# Altium schematic sheet ACTIVATOR.SchDoc
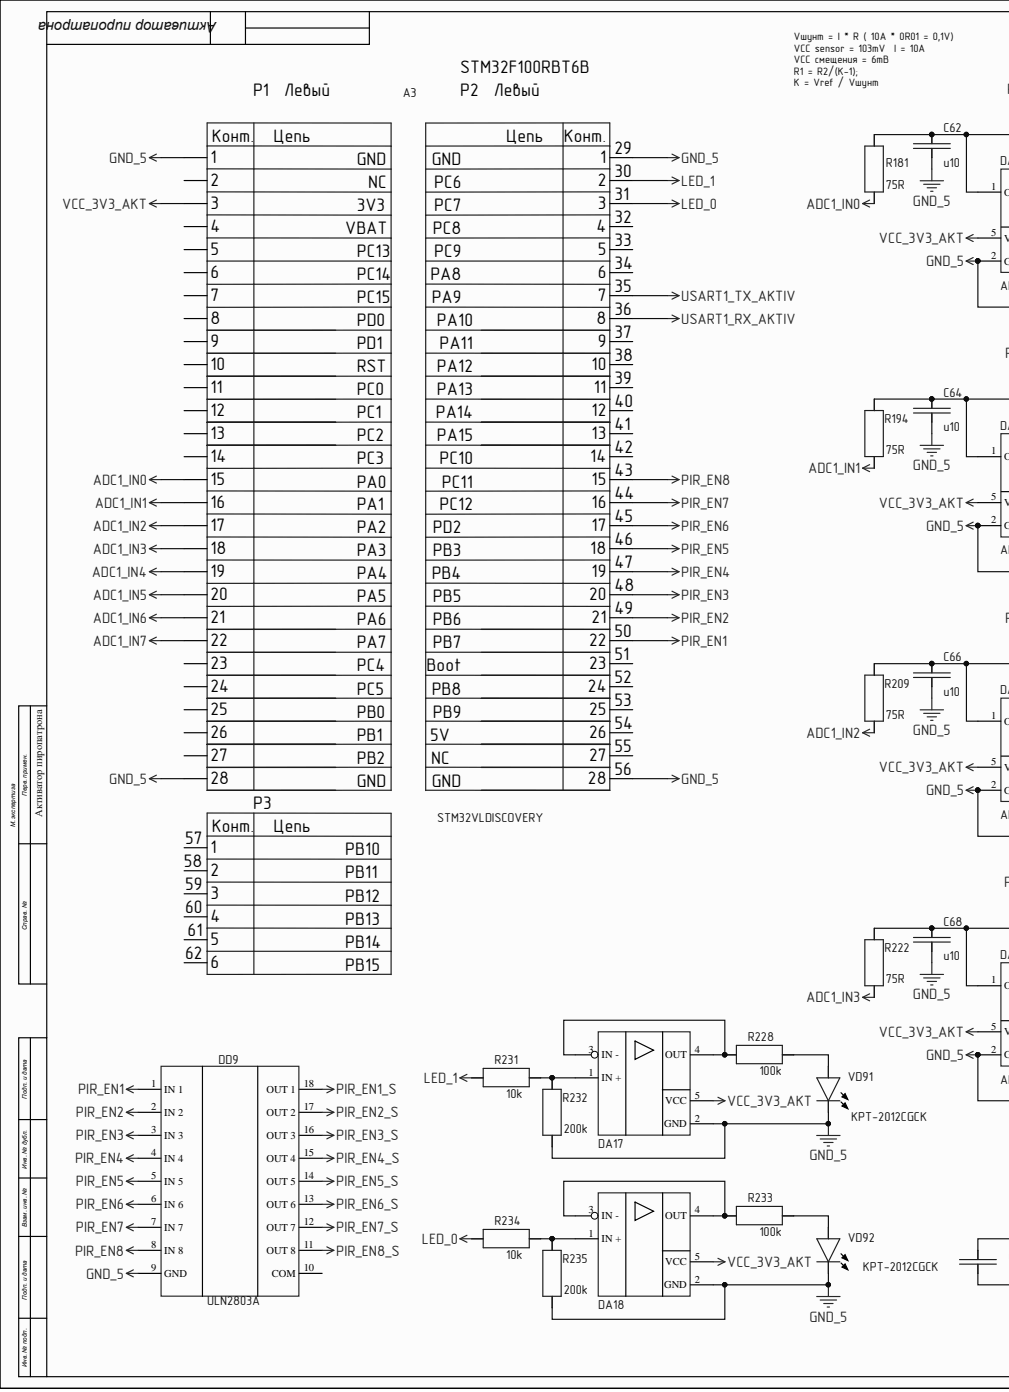
[diagram p0: ACTIVATOR.SchDoc, left]
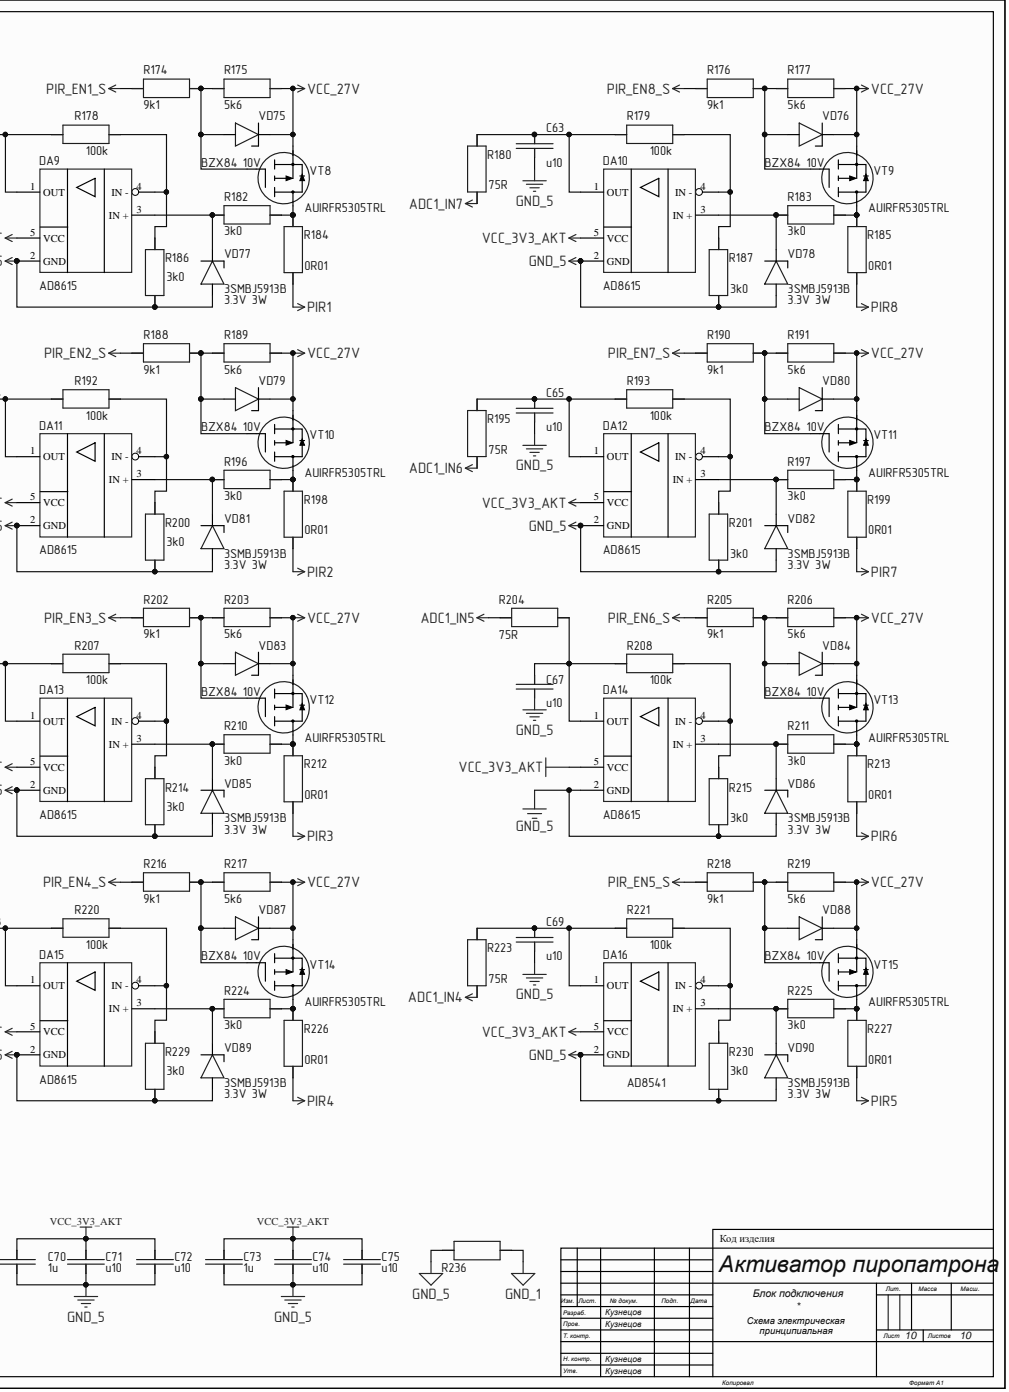
[diagram p1: ACTIVATOR.SchDoc, right]

## Parts
A3  STM32VLDISCOVERY  [STM32VLDISCOVERY]
C62  u10
C63  u10
C64  u10
C65  u10
C66  u10
C67  u10
C68  u10
C69  u10
C70  1u
C71  u10
C72  u10
C73  1u
C74  u10
C75  u10
DA9  AD8615  [SOT-23-5]
DA10  AD8615  [SOT-23-5]
DA11  AD8615  [SOT-23-5]
DA12  AD8615  [SOT-23-5]
DA13  AD8615  [SOT-23-5]
DA14  AD8615  [SOT-23-5]
DA15  AD8615  [SOT-23-5]
DA16  AD8541  [SOT-23-5]
DA17  TSV631  [SOT-23-5]  (value not drawn)
DA18  TSV631  [SOT-23-5]  (value not drawn)
DD9  ULN2803A  [SOIC-18]
R174  9k1  [0805]
R175  5k6  [0805]
R176  9k1  [0805]
R177  5k6  [0805]
R178  100k  [0805]
R179  100k  [0805]
R180  75R  [0805]
R181  75R  [0805]
R182  3k0  [0805]
R183  3k0  [0805]
R184  0R01  [R2010]
R185  0R01  [R2010]
R186  3k0  [0805]
R187  3k0  [0805]
R188  9k1  [0805]
R189  5k6  [0805]
R190  9k1  [0805]
R191  5k6  [0805]
R192  100k  [0805]
R193  100k  [0805]
R194  75R  [0805]
R195  75R  [0805]
R196  3k0  [0805]
R197  3k0  [0805]
R198  0R01  [R2010]
R199  0R01  [R2010]
R200  3k0  [0805]
R201  3k0  [0805]
R202  9k1  [0805]
R203  5k6  [0805]
R204  75R  [0805]
R205  9k1  [0805]
R206  5k6  [0805]
R207  100k  [0805]
R208  100k  [0805]
R209  75R  [0805]
R210  3k0  [0805]
R211  3k0  [0805]
R212  0R01  [R2010]
R213  0R01  [R2010]
R214  3k0  [0805]
R215  3k0  [0805]
R216  9k1  [0805]
R217  5k6  [0805]
R218  9k1  [0805]
R219  5k6  [0805]
R220  100k  [0805]
R221  100k  [0805]
R222  75R  [0805]
R223  75R  [0805]
R224  3k0  [0805]
R225  3k0  [0805]
R226  0R01  [R2010]
R227  0R01  [R2010]
R228  100k  [0805]
R229  3k0  [0805]
R230  3k0  [0805]
R231  10k  [0805]
R232  200k  [0805]
R233  100k  [0805]
R234  10k  [0805]
R235  200k  [0805]
R236  (no value)  [R2010]
VD75  BZX84  value BZX84 10V  [SOT23]
VD76  BZX84  value BZX84 10V  [SOT23]
VD77  3SMBJ5913B  [DO-214AА(SMB)]
VD78  3SMBJ5913B  Power\Voltage: 3.3V 3W  [DO-214AА(SMB)]
VD79  BZX84  value BZX84 10V  [SOT23]
VD80  BZX84  value BZX84 10V  [SOT23]
VD81  3SMBJ5913B  [DO-214AА(SMB)]
VD82  3SMBJ5913B  [DO-214AА(SMB)]
VD83  BZX84  value BZX84 10V  [SOT23]
VD84  BZX84  value BZX84 10V  [SOT23]
VD85  3SMBJ5913B  [DO-214AА(SMB)]
VD86  3SMBJ5913B  Power\Voltage: 3.3V 3W  [DO-214AА(SMB)]
VD87  BZX84  value BZX84 10V  [SOT23]
VD88  BZX84  value BZX84 10V  [SOT23]
VD89  3SMBJ5913B  Power\Voltage: 3.3V 3W  [DO-214AА(SMB)]
VD90  3SMBJ5913B  Power\Voltage: 3.3V 3W  [DO-214AА(SMB)]
VD91  KPT-2012CGCK  [0805_LED_GREEN]
VD92  KPT-2012CGCK  [0805_LED_GREEN]
VT8  AUIRFR5305TRL  [TO252AA (D-PAK)]
VT9  AUIRFR5305TRL  [TO252AA (D-PAK)]
VT10  AUIRFR5305TRL  [TO252AA (D-PAK)]
VT11  AUIRFR5305TRL  [TO252AA (D-PAK)]
VT12  AUIRFR5305TRL  [TO252AA (D-PAK)]
VT13  AUIRFR5305TRL  [TO252AA (D-PAK)]
VT14  AUIRFR5305TRL  [TO252AA (D-PAK)]
VT15  AUIRFR5305TRL  [TO252AA (D-PAK)]

## Nets
ADC1_IN0: A3.15 R181.2
ADC1_IN1: A3.16 R194.2
ADC1_IN2: A3.17 R209.2
ADC1_IN3: A3.18 R222.2
ADC1_IN4: A3.19 R223.2
ADC1_IN5: A3.20 R204.2
ADC1_IN6: A3.21 R195.2
ADC1_IN7: A3.22 R180.2
GND_1: R236.2
GND_5: A3.1 A3.28 A3.29 A3.56 C62.2 C63.2 C64.2 C65.2 C66.2 C67.2 C68.2 C69.2 C70.1 C71.1 C72.1 C73.1 C74.1 C75.1 DA9.2(GND) DA10.2(GND) DA11.2(GND) DA12.2(GND) DA13.2(GND) DA14.2(GND) DA15.2(GND) DA16.2(GND) DA17.2(GND) DA18.2(GND) DD9.9(GND) R186.2 R187.2 R200.2 R201.2 R214.2 R215.2 R229.2 R230.2 R232.2 R235.2 R236.1 VD77.1 VD78.1 VD81.1 VD82.1 VD85.1 VD86.1 VD89.1 VD90.1 VD91.2 VD92.2
LED_0: A3.31 R234.2
LED_1: A3.30 R231.2
PIR1: R184.1
PIR2: R198.1
PIR3: R212.1
PIR4: R226.1
PIR5: R227.1
PIR6: R213.1
PIR7: R199.1
PIR8: R185.1
PIR_EN1: A3.50 DD9.1(IN_1)
PIR_EN1_S: DD9.18(OUT_1) R174.2
PIR_EN2: A3.49 DD9.2(IN_2)
PIR_EN2_S: DD9.17(OUT_2) R188.2
PIR_EN3: A3.48 DD9.3(IN_3)
PIR_EN3_S: DD9.16(OUT_3) R202.2
PIR_EN4: A3.47 DD9.4(IN_4)
PIR_EN4_S: DD9.15(OUT_4) R216.2
PIR_EN5: A3.46 DD9.5(IN_5)
PIR_EN5_S: DD9.14(OUT_5) R218.2
PIR_EN6: A3.45 DD9.6(IN_6)
PIR_EN6_S: DD9.13(OUT_6) R205.2
PIR_EN7: A3.44 DD9.7(IN_7)
PIR_EN7_S: DD9.12(OUT_7) R190.2
PIR_EN8: A3.43 DD9.8(IN_8)
PIR_EN8_S: DD9.11(OUT_8) R176.2
USART1_RX_AKTIV: A3.36
USART1_TX_AKTIV: A3.35
VCC_3V3_AKT: A3.3 C70.2 C71.2 C72.2 C73.2 C74.2 C75.2 DA9.5(VCC) DA10.5(VCC) DA11.5(VCC) DA12.5(VCC) DA13.5(VCC) DA14.5(VCC) DA15.5(VCC) DA16.5(VCC) DA17.5(VCC) DA18.5(VCC)
VCC_27V: R175.1 R177.1 R189.1 R191.1 R203.1 R206.1 R217.1 R219.1 VD75.3 VD76.3 VD79.3 VD80.3 VD83.3 VD84.3 VD87.3 VD88.3 VT8.3 VT9.3 VT10.3 VT11.3 VT12.3 VT13.3 VT14.3 VT15.3
NetC62_1: C62.1 DA9.1(OUT) R178.2 R181.1
NetC63_1: C63.1 DA10.1(OUT) R179.2 R180.1
NetC64_1: C64.1 DA11.1(OUT) R192.2 R194.1
NetC65_1: C65.1 DA12.1(OUT) R193.2 R195.1
NetC66_1: C66.1 DA13.1(OUT) R207.2 R209.1
NetC67_1: C67.1 DA14.1(OUT) R204.1 R208.2
NetC68_1: C68.1 DA15.1(OUT) R220.2 R222.1
NetC69_1: C69.1 DA16.1(OUT) R221.2 R223.1
NetDA9_3: DA9.3(IN_+) R182.2 VD77.2
NetDA9_4: DA9.4(IN_-) R178.1 R186.1
NetDA10_3: DA10.3(IN_+) R183.2 VD78.2
NetDA10_4: DA10.4(IN_-) R179.1 R187.1
NetDA11_3: DA11.3(IN_+) R196.2 VD81.2
NetDA11_4: DA11.4(IN_-) R192.1 R200.1
NetDA12_3: DA12.3(IN_+) R197.2 VD82.2
NetDA12_4: DA12.4(IN_-) R193.1 R201.1
NetDA13_3: DA13.3(IN_+) R210.2 VD85.2
NetDA13_4: DA13.4(IN_-) R207.1 R214.1
NetDA14_3: DA14.3(IN_+) R211.2 VD86.2
NetDA14_4: DA14.4(IN_-) R208.1 R215.1
NetDA15_3: DA15.3(IN_+) R224.2 VD89.2
NetDA15_4: DA15.4(IN_-) R220.1 R229.1
NetDA16_3: DA16.3(IN_+) R225.2 VD90.2
NetDA16_4: DA16.4(IN_-) R221.1 R230.1
NetDA17_1: DA17.1(IN_+) R231.1 R232.1
NetDA17_3: DA17.3(IN_-) DA17.4(OUT) R228.2
NetDA18_1: DA18.1(IN_+) R234.1 R235.1
NetDA18_3: DA18.3(IN_-) DA18.4(OUT) R233.2
NetR174_1: R174.1 R175.2 VD75.1 VT8.1
NetR176_1: R176.1 R177.2 VD76.1 VT9.1
NetR182_1: R182.1 R184.2 VT8.2
NetR183_1: R183.1 R185.2 VT9.2
NetR188_1: R188.1 R189.2 VD79.1 VT10.1
NetR190_1: R190.1 R191.2 VD80.1 VT11.1
NetR196_1: R196.1 R198.2 VT10.2
NetR197_1: R197.1 R199.2 VT11.2
NetR202_1: R202.1 R203.2 VD83.1 VT12.1
NetR205_1: R205.1 R206.2 VD84.1 VT13.1
NetR210_1: R210.1 R212.2 VT12.2
NetR211_1: R211.1 R213.2 VT13.2
NetR216_1: R216.1 R217.2 VD87.1 VT14.1
NetR218_1: R218.1 R219.2 VD88.1 VT15.1
NetR224_1: R224.1 R226.2 VT14.2
NetR225_1: R225.1 R227.2 VT15.2
NetR228_1: R228.1 VD91.1
NetR233_1: R233.1 VD92.1

Not connected: A3 2 4 5 6 7 8 9 10 11 12 13 14 23 24 25 26 27 32 33 34 37 38 39 40 41 42 51 52 53 54 55 57 58 59 60 61 62; DD9 10(COM)

## Library data of the parts
A3 = STM32VLDISCOVERY: STM32F767, mbed-Enabled Development Nucleo STM32F7; Manufacturer: STMicroelectronics; Component Kind: Standard; ComponentLink1Description: Параметры; ComponentLink2Description: Сайт производителя; ManufacturerPartNumber: STM32VLDISCOVERY; Author: Eleman; Library Name: Eleman_Plc.SchLib
C62-C69 = (no symbol name): Capacitor; Power\Voltage: 25V; Tolerance: 10%; Корпус: 0805; Component Kind: Standard; ComponentLink1Description: Параметры; ComponentLink2Description: Сайт производителя; Value: u10; Author: Eleman; Library Name: eleman_capacitor.schlib
C70-C75 = (no symbol name): Capacitor; Power\Voltage: 25V; TKE: 5XR; Tolerance: 10%; Корпус: 0805; Component Kind: Standard; ComponentLink1Description: Параметры; ComponentLink2Description: Сайт производителя; Author: Eleman; Library Name: eleman_capacitor.schlib
  C70 C73: Value: 1u
  C71 C72 C74 C75: Value: u10
DA9-DA15 = AD8615: Операционный усилитель; Manufacturer: Analog Devices Inc.; ManufacturerPartNumber: AD8615AUJZ-REEL7; Component Kind: Standard; ComponentLink1Description: Параметры; ComponentLink2Description: Сайт производителя; Корпус: SOT-23-5; Author: Rodnik SPE; ComponentLink1URL: C:\Demo\Datasheet\MAX6816-MAX6818.pdf; Library Name: Eleman_Amplifier.SchLib
DA16 = AD8541: Операционный усилитель; Manufacturer: Analog Devices Inc.; ManufacturerPartNumber: AD8541ARTZ-REEL7; Component Kind: Standard; ComponentLink1Description: Параметры; ComponentLink2Description: Сайт производителя; Корпус: SOT-23-5; Author: Rodnik SPE; ComponentLink1URL: C:\Demo\Datasheet\MAX6816-MAX6818.pdf; Library Name: Eleman_Amplifier.SchLib
DA17 DA18 = TSV631: Операционный усилитель; Manufacturer: STMicroelectronics; ManufacturerPartNumber: TSV631AILT; Component Kind: Standard; ComponentLink1Description: Параметры; ComponentLink2Description: Сайт производителя; Корпус: SOT-23-5; Author: Eleman; ComponentLink1URL: C:\Demo\Datasheet\MAX6816-MAX6818.pdf; Library Name: Eleman_Amplifier.SchLib
DD9 = ULN2803A: Транзисторная сборка; Manufacturer: Texas Instruments; ManufacturerPartNumber: ULN2803ADWR; Power\Voltage: 50V  500mA; Корпус: 16-TSSOP; Component Kind: Standard; ComponentLink1Description: Параметры; ComponentLink2Description: Сайт производителя; Supplier 1: Farnell; Supplier Part Number 1: 1652419; Author: Eleman; Library Name: Eleman_Transistor.SchLib
R174 R175 R178 R182 R186 R188 R189 = R0805: Импортный постоянный углеродистый резистор; Manufacturer: Vishay Dale; Power\Voltage: 0.125W; Tolerance: 1%; Component Kind: Standard; ComponentLink1Description: Параметры; ComponentLink2Description: Сайт производителя; ManufacturerPartNumber: R0805; Корпус: SMD 0805; Author: Eleman; Library Name: Eleman_Resistor.SchLib
  R174 R188: Value: 9k1
  R175 R189: Value: 5k6
  R178: Value: 100k
  R182 R186: Value: 3k0
R176 R177 R179-R181 R183 R187 R190-R197 R200-R211 R214-R225 R228-R235 = R0805: Импортный постоянный углеродистый резистор; Manufacturer: Vishay Dale; Power\Voltage: 0.125W; Tolerance: 5%; Component Kind: Standard; ComponentLink1Description: Параметры; ComponentLink2Description: Сайт производителя; ManufacturerPartNumber: R0805; Корпус: SMD 0805; Author: Eleman; Library Name: Eleman_Resistor.SchLib
  R176 R190 R202 R205 R216 R218: Value: 9k1
  R177 R191 R203 R206 R217 R219: Value: 5k6
  R179 R192 R193 R207 R208 R220 R221 R228 R233: Value: 100k
  R180 R181 R194 R195 R204 R209 R222 R223: Value: 75R
  R183 R187 R196 R197 R200 R201 R210 R211 R214 R215 R224 R225 R229 R230: Value: 3k0
  R231 R234: Value: 10k
  R232 R235: Value: 200k
R184 R185 R198 R199 R212 R213 R226 R227 = R2010: Импортный постоянный углеродистый резистор; Manufacturer: Vishay Dale; Power\Voltage: 0.75W; Tolerance: 1%; Component Kind: Standard; ComponentLink1Description: Параметры; ComponentLink2Description: Сайт производителя; ManufacturerPartNumber: R2010; Value: 0R01; Корпус: SMD 2010; Author: Eleman; Library Name: Eleman_Resistor.SchLib
R236 = R2010: Импортный постоянный углеродистый резистор; Manufacturer: Vishay Dale; Power\Voltage: 0.75W; Tolerance: 5%; Component Kind: Standard; ComponentLink1Description: Параметры; ComponentLink2Description: Сайт производителя; ManufacturerPartNumber: R2010; Корпус: SMD 2010; Author: Eleman; Library Name: Eleman_Resistor.SchLib
VD75 VD76 VD79 VD80 VD83 VD84 VD87 VD88 = BZX84: Диод  Zener; Manufacturer: Vishay Semiconductor Diodes Division; ManufacturerPartNumber: BZX84C10-E3-08; Power\Voltage: 10V 300mW; Tolerance: 5%; Value: BZX84 10V; Корпус: SOT-23-3; Component Kind: Standard; ComponentLink1Description: Параметры; ComponentLink2Description: Сайт производителя; Author: Eleman; Library Name: Eleman_Diods.SchLib
VD77 VD81 VD82 VD85 = 3SMBJ5913B: Диод  Zener; Manufacturer: Micro Commercial Co; ManufacturerPartNumber: 3SMBJ5913B-TP; Power\Voltage: 3.3V 3W; Tolerance: 5%; Корпус: DO-214AA; Component Kind: Standard; ComponentLink1Description: Параметры; ComponentLink2Description: Сайт производителя; Author: Eleman; Library Name: Eleman_Diods.SchLib
VD78 VD86 VD89 VD90 = 3SMBJ5913B: Диод  Zener; Manufacturer: Micro Commercial Co; ManufacturerPartNumber: 3SMBJ5913B-TP; Tolerance: 5%; Корпус: DO-214AA; Component Kind: Standard; ComponentLink1Description: Параметры; ComponentLink2Description: Сайт производителя; Author: Eleman; Library Name: Eleman_Diods.SchLib
VD91 VD92 = KPT-2012CGCK: Светодиод/Зеленый/Чип; Manufacturer: KINGBRIGHT; Корпус: 0805; Component Kind: Standard; ComponentLink1Description: Параметры; ComponentLink2Description: Сайт производителя; ManufacturerPartNumber: KPT-2012CGCK; Value: KPT-2012CGCK; Author: Eleman; ComponentLink1URL: d:\YandexDisk\Project_Altium\Lib_Eleman\; Library Name: Eleman_Diods.SchLib
VT8-VT15 = AUIRFR5305TRL: Транзистор MOSFET  P-Channel; Power\Voltage: 55V  31A; Корпус: D-Pak; Component Kind: Standard; ComponentLink1Description: Параметры; ComponentLink2Description: Сайт производителя; ManufacturerPartNumber: AUIRFR5305TRL; Rem: P-Channel; Author: Eleman; Library Name: Eleman_Transistor.SchLib
